# Altium schematic sheet DISPLAY-RELE.SchDoc(DISPLAY-RELE)
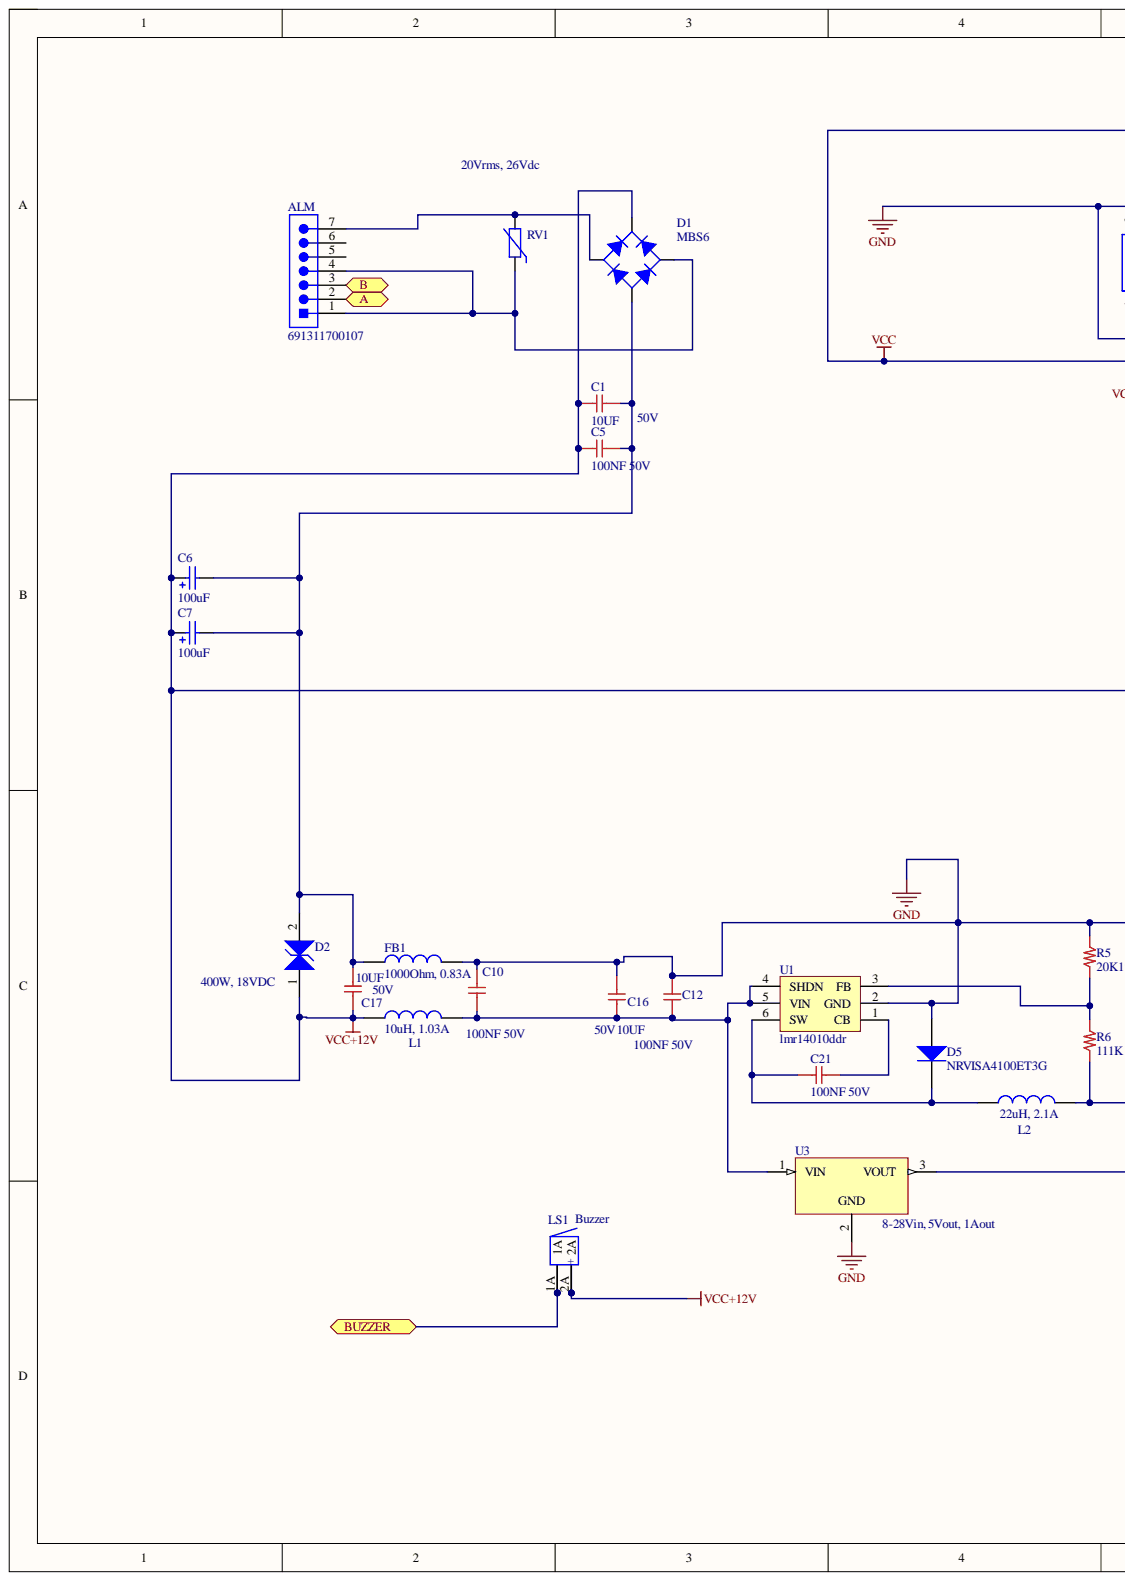
[diagram p0: DISPLAY-RELE.SchDoc, left]
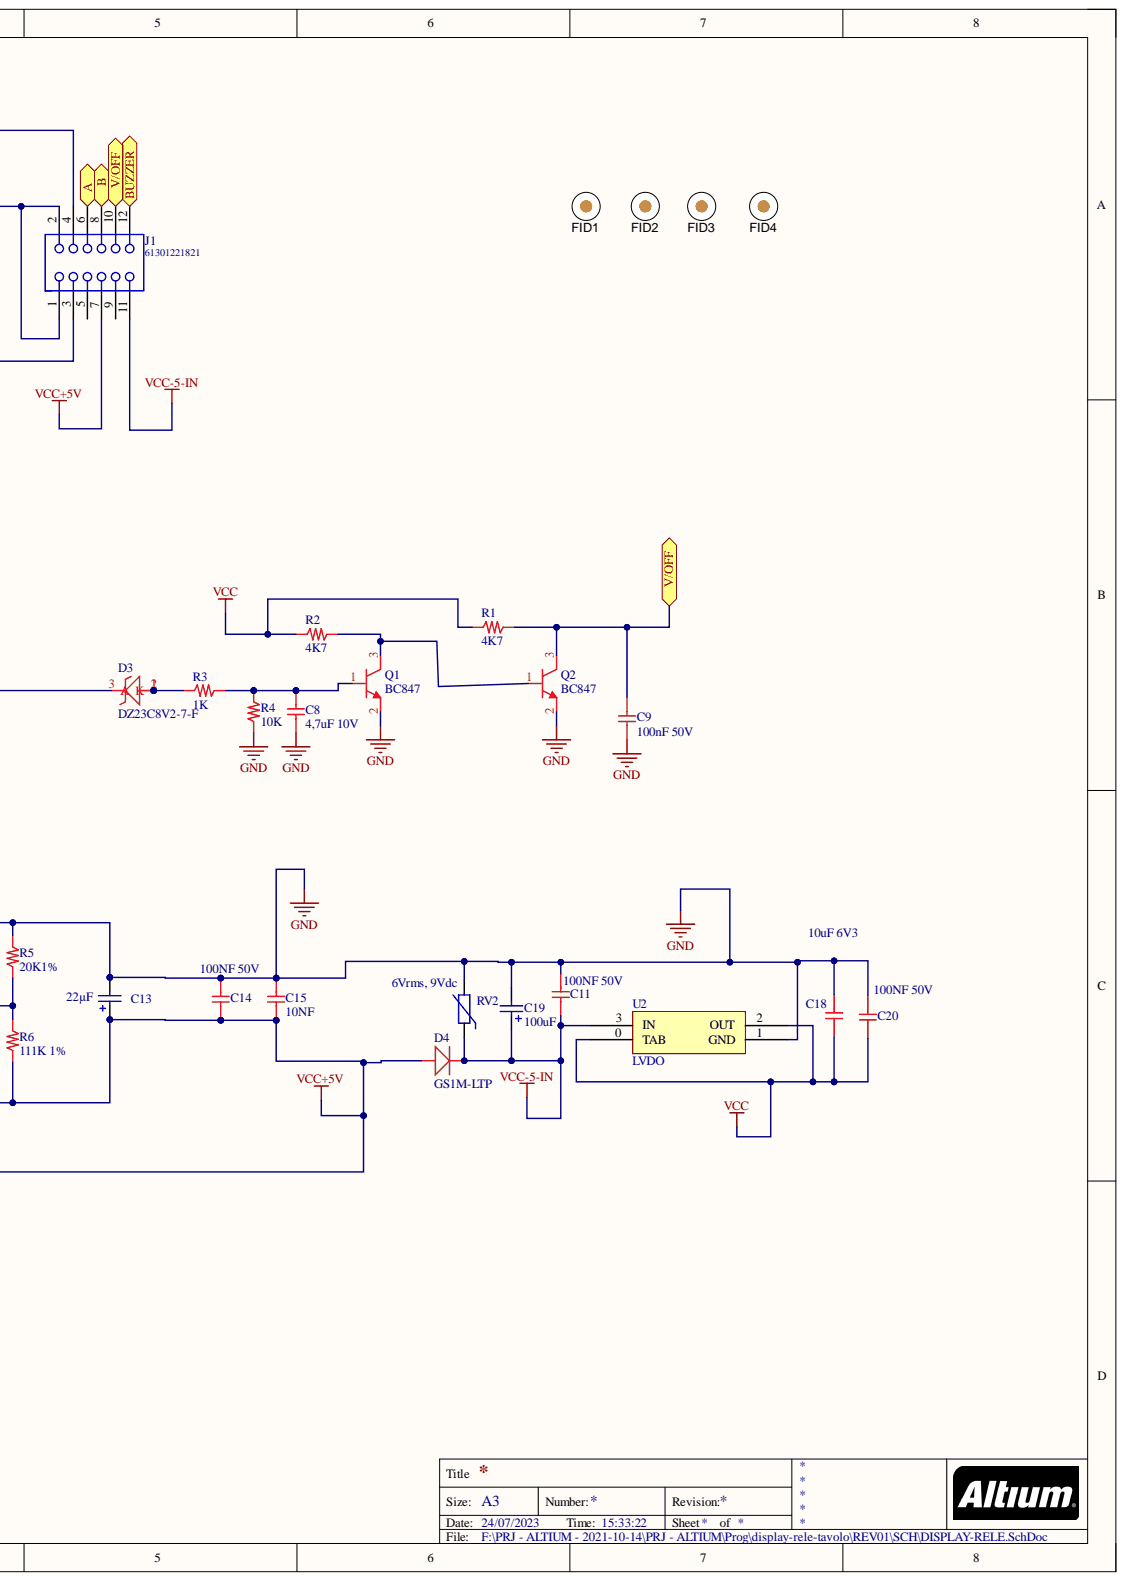
[diagram p1: DISPLAY-RELE.SchDoc, right]

## Parts
ALM  691311700107  [691311700107]
C1  10UF  Tensione: 50V  [1206_C]
C5  100NF 50V  [0603_c]
C6  value 100uF  [WCAP-ASLL_6.3x7.7]  (hidden comment: 865060445005)
C7  value 100uF  [WCAP-ASLL_6.3x7.7]  (hidden comment: 865060445005)
C8  4,7uF 10V  [0603_c]
C9  100nF 50V  [0603_c]
C10  100NF 50V  [0603_c]
C11  100NF 50V  [0603_c]
C12  100NF 50V  [0603_c]
C13  22µF  [WCAP-PSLP_D4H5.5]
C14  100NF 50V  [0603_c]
C15  10NF  [0603_c]
C16  10UF  Tensione: 50V  [1206_C]
C17  10UF  Tensione: 50V  [1206_C]
C18  10uF 6V3  [0603_c]
C19  value 100uF  [WCAP-ASLL_6.3x7.7]  (hidden comment: 865060445005)
C20  100NF 50V  [0603_c]
C21  100NF 50V  [0603_c]
D1  MBS6  [HD01-T SOP4]
D2  400W, 18VDC  [WE-TVSP_DO-214AC_Bidirectional]
D3  DZ23C8V2-7-F  [SOT23]
D4  GS1M-LTP  [SMA]
D5  NRVISA4100ET3G  [DO-214AC -B]
FB1  1000Ohm, 0.83A  Rated Current: 0.83A  [WE-CBF_0603_Wide Band]
FID1  Fiducial  [Fiducial10-20]  (value not drawn)
FID2  Fiducial  [Fiducial10-20]  (value not drawn)
FID3  Fiducial  [Fiducial10-20]  (value not drawn)
FID4  Fiducial  [Fiducial10-20]  (value not drawn)
J1  61301221821  [61301221821]
L1  10uH, 1.03A  Rated Current: 1.03A  [WE-LQS_3012]
L2  22uH, 2.1A  Rated Current: 2.1A  [WE-LQS_6045]
LS1  Buzzer  [BUZZER-14mm]
Q1  BC847  [SOT23]
Q2  BC847  [SOT23]
R1  4K7  [0603_R]
R2  4K7  [0603_R]
R3  1K  [0603_R]
R4  10K  [0603_R]
R5  20K1%  [0603_R]
R6  111K 1%  [0603_R]
RV1  20Vrms, 26Vdc  [WE-VD_5mm_820452001]
RV2  6Vrms, 9Vdc  DC Operating Voltage: 9Vdc  [WE-VS_0805]
U1  lmr14010ddr  [SOT23-6_N]
U2  LVDO  [SOT-223]
U3  8-28Vin, 5Vout, 1Aout  [MagI3C-FDSM_SIP-3_L11.6W8.5H10.5]

## Ports
A, B, BUZZER, V/OFF

## Nets
GND: C8.2 C9.2 C10.2 C11.2 C12.2 C13.2 C14.2 C15.2 C16.1 C18.1 C19.2 C20.2 D5.2 FB1.2 J1.1 J1.2 Q1.2 Q2.2 R4.2 R5.1 RV2.2 U1.2(GND) U2.1(GND) U3.2
VCC: C18.2 C20.1 J1.3 J1.4 R1.2 R2.2 U2.0(TAB) U2.2(OUT)
VCC+5V: C13.1 C14.1 C15.1 D4.1 J1.7 L2.2 R6.2 U3.3
VCC+12V: C1.2 C5.2 C6.1 C7.1 C17.2 D1.1 D2.1 D3.3 L1.1 LS1.2 LS1.2A
VCC-5-IN: C11.1 C19.1 D4.2 J1.11 RV2.1 U2.3(IN)
A: ALM.2 J1.6 ; port A
B: ALM.3 J1.8 ; port B
BUZZER: J1.12 LS1.1(1A) LS1.1A ; port BUZZER
V/OFF: C9.1 J1.10 Q2.3 R1.1 ; port V/OFF
NetALM_1: ALM.1 ALM.4 D1.3 RV1.1
NetALM_7: ALM.7 D1.4 RV1.2
NetC1_1: C1.1 C5.1 C6.2 C7.2 C17.1 D1.2 D2.2 FB1.1
NetC8_1: C8.1 Q1.1 R3.1 R4.1
NetC10_1: C10.1 C12.1 C16.2 L1.2 U1.4(SHDN) U1.5(VIN) U3.1
NetC21_1: C21.1 D5.1 L2.1 U1.6(SW)
NetC21_2: C21.2 U1.1(CB)
NetD3_1: D3.1 D3.2 R3.2
NetQ1_3: Q1.3 Q2.1 R2.1
NetR5_2: R5.2 R6.1 U1.3(FB)

Not connected: ALM 5 6; J1 5 9

## Library data of the parts
ALM = 691311700107: WR-TBL Serie 3117 Close Vertical PCB Header, Pluggable, THT, pitch 5mm, 7p; Manufacturer: Wurth Elektronik; Modularity: No; Pin Number: 7; Rated Current [UL]: 20A; Working Voltage [UL]: 300VAC; Application: Pluggable; Component Kind: Standard; ComponentLink1Description: Manufacturer; ComponentLink1URL: http://www.we-online.de; ComponentLink2Description: Datasheet; ComponentLink2URL: https://katalog.we-online.de/em/datasheet/691311700107.pdf; Library Name: WR-TBL_Pluggable PCB Header.SchLib; Manufacturer Part Number: 691311700107; Mounting Technology: THT; Operating Temperature: -40 to +105degC; Packaging: Box; PCB/Cable/Panel: PCB; Pitch: 5mm; Published Date: Feburary 2021; Type: Vertical
C1 C16 C17 = 10UF 50V: Cap X5R 10UF 50V; Cod. Produttore: GRM31CR61H106KA12L; Produttore: MURATA; Cod. Farnell: 2666583; Component Kind: Standard; Library Name: CapSMD1206.SchLib; Temperature Range: Da -55°C a +125°C
C5 C8-C12 C14 C20 C21 = 100NF 50V: Cap X7R 100nF 50V Flex Terminal; Cod. Interno: COCS50665050104; Cod. Produttore: CL10B104KB8SFNC; Produttore: Samsung; Cod. DigiKey: 1276-1936-2-ND; Component Kind: Standard; Library Name: CapSMD0603.SchLib
C6 C7 C19 = 865060445005: WCAP-ASLL Aluminum Electrolytic Capacitors, size Ф6.3x7.7mm, 25V DC, 100uF; Rated Voltage: 25V DC; Component Kind: Standard; ComponentLink1Description: Manufacturer; ComponentLink1URL: http://www.we-online.de; ComponentLink2Description: Datasheet; ComponentLink2URL: http://katalog.we-online.de/pbs/datasheet/865060445005.pdf; Library Name: WCAP-ASLL.SchLib; Life Cycle: 2000h; Operating Temperature: -55 °C up to +105 °C; Part Number: 865060445005; Published Date: June 2017; Size: Ф6.3x7.7mm; Publisher: Wurth Elektronik
C13 = 875105240003: WCAP-PSLP Aluminum Polymer Capacitor, V-Chip SMT, D4 x H5.5mm, 22µF, 10V; Manufacturer: Wurth Elektronik; Capacitance Tolerance: +/-20%; Dissipation Factor: 8%; Endurance: 2000h; ESR: 45mΩ; Leakage Current: 300µA; Ripple Current: 1200mA; Technical Reference: PSEB055220M010DVCTAB000; Capacitance: 22µF; Category: Aluminum Polymer Capacitors; Component Kind: Standard; ComponentLink1Description: Website Link; ComponentLink1URL: https://www.we-online.com/redexpert/article/875105240003?ad; ComponentLink2Description: Datasheet Link; ComponentLink2URL: https://www.we-online.com/redexpert/spec/875105240003?ad; Diameter: 4mm; Height: 5.5mm; Library Name: WCAP-PSLP.SchLib; Manufacturer Part Number: 875105240003; Match Code: WCAP-PSLP; Mount: Surface Mount; Operating Temperature Max: 105°C; Operating Temperature Min: -55°C; Packaging: Tape and Reel; Rated Voltage (DC): 10V
C15 = 10NF 50V: Cap X7R 10nF 50V; Cod. Interno: COCS 556655000103; Cod. Produttore: CL05B103KB5NNNC; Produttore: Samsung; Cod. DigiKey: 1276-1028-2-ND; Component Kind: Standard; Library Name: CapSMD0603.SchLib
C18 = 10uF 6V3: 10uF 6V3, ±10%, X5R 0603; Cod. Interno: COCS??; Cod. Produttore: C1608X5R1C335K080AC; Produttore: TDK; Component Kind: Standard; Library Name: CapSMD0603.SchLib
D1 = HD01-T: Full Wave Diode Bridge; Component Kind: Standard; Library Name: HSW.SchLib; Publisher: Altium Limited
D2 = 824501181: WE-TVSP Power TVS Diode, DO-214AC, 400W, 18VDC, Bidirectional; (Forward) Peak Pulse Current: 13.7A; (Reverse) Breakdown Voltage: 21.05V; Clamping Voltage: 29.2V; DC Operating Voltage: 18V; Component Kind: Standard; ComponentLink1Description: Manufacturer; ComponentLink1URL: http://www.we-online.de; ComponentLink2Description: Datasheet; ComponentLink2URL: https://katalog.we-online.com/pbs/datasheet/824501181.pdf; Library Name: WE-TVSP_DO-214AC_Bidirectional.SchLib; Mounting Technology: SMT; Operating Temperature: -65 to +150degC; Packaging: Tape and Reel; Part Number: 824501181; Polarity: Bidirectional; Published Date: March 2019; Size: DO-214AC; Steady State Power Dissipation: 400W; Publisher: Wurth Elektronik
D3 = ZENER 8V2 500mW: DIODE ZENER 8V2 500MW SOT23; Cod. Interno: ZENS5235PLVA650A; Cod. Produttore: PLVA650A; Produttore: NXP; Cod. DigiKey: 568-6471-2-ND; Component Kind: Standard; Library Name: DiodiZENER.SchLib
D4 = GS1M-LTP: 1 Amp Glass  Passivated Rectifier  50 - 1000 Volts; Cod. Interno: DIO 341004148; Cod. Produttore: 1N4148; If: 200mA; Ifrp: 450mA; Produttore: NXP; Vr: 100V; Component Kind: Standard; Library Name: Diodi.SchLib
D5 = BYS-10-45: High Conductance Fast Diode; Code_JEDEC: DO-204-AH; Component Kind: Standard; ComponentLink1Description: Manufacturer Link; ComponentLink1URL: http://www.fairchildsemi.com/; ComponentLink2Description: Datasheet; ComponentLink2URL: http://www.fairchildsemi.com/ds/1N%2F1N4148.pdf; DatasheetVersion: 1997; LatestRevisionDate: 17-Jul-2002; LatestRevisionNote: Re-released for DXP Platform.; PackageDescription: Diode, Axial; Body 3.8 x 1.9 mm (LxDia. max), Lead Dia. 0.53 mm (max); PackageReference: DO-35; Published: 8-Jun-2000; Library Name: HSW.SchLib; Publisher: Altium Limited
FB1 = 742792662: WE-CBF SMT EMI Suppression Ferrite Bead, size 0603, 1000Ohm, 0.83A; DC Resistance max.: 0.3Ohm; Impedance max.: 1050Ohm; Type: Wide Band; Component Kind: Standard; ComponentLink1Description: Manufacturer; ComponentLink1URL: http://www.we-online.de; ComponentLink2Description: Datasheet; ComponentLink2URL: https://katalog.we-online.com/pbs/datasheet/742792662.pdf; Impedance@100 MHz: 1000Ohm; Library Name: WE-CBF_0603.SchLib; Mounting Technology: SMT; Operating Temperature: -55 to +125degC; Packaging: Tape and Reel; Part Number: 742792662; Published Date: March 2019; Size: 0603; Publisher: Wurth Elektronik
FID1-FID4 = Fiducial: Fiducial mark.  There is nothing to buy or mount.; SymbolCreator: codlsc; ClassName: FiducialMark; Component Kind: Standard; CompType: Fiducial; LatestRevisionDate: 2015-10-18; LatestRevisionNote: Added Alternate PartNumber and Alternate Manufacturer parameters to appropriate components.; Manufacturer: N/A; MountType: N/A; PackageReference: N/A; PartNumber: N/A; SymbolVersion: 2009-11-4; Library Name: HSW.SchLib; Publisher: Texas Instruments
J1 = 61301221821: WR-PHD Socket Header, THT, pitch 2.54mm, Dual Row, Vertical, 12p; Manufacturer: Wurth Elektronik; Contact Resistance: 20mOhm; Pin Number: 12; Rated Current: 3A; Withstanding Voltage: 500VAC; Working Voltage: 250VAC; Component Kind: Standard; ComponentLink1Description: Manufacturer; ComponentLink1URL: http://www.we-online.de; ComponentLink2Description: Datasheet; ComponentLink2URL: https://www.we-online.com/catalog/datasheet/61301221821.pdf; Gender: Socket Header; Library Name: WR-PHD_Socket Header_2.54mm.SchLib; Manufacturer Part Number: 61301221821; Mounting Technology: THT; Operating Temperature: -40 to +125degC; Orientation: Vertical; Packaging: Tray; Pitch: 2.54mm; Published Date: May 2020; Row Number: Dual
L1 = 74404031100A: WE-LQS SMT Power Inductor, size 3012, 10uH, 1.03A; Manufacturer: Wurth Elektronik; DC Resistance: 254mOhm; Saturation Current: 0.58A; Self Resonant Frequency: 58MHz; Component Kind: Standard; ComponentLink1Description: Manufacturer; ComponentLink1URL: http://www.we-online.de; ComponentLink2Description: Datasheet; ComponentLink2URL: https://www.we-online.com/catalog/datasheet/74404031100A.pdf; Inductance: 10uH; Library Name: WE-LQS_3012.SchLib; Manufacturer Part Number: 74404031100A; Mounting Technology: SMT; Operating Temperature: -40 to +125degC; Packaging: Tape and Reel; Published Date: May 2020; Size: 3012
L2 = 74404064220: WE-LQS SMT Power Inductor, size 6045, 22uH, 2.1A; Manufacturer: Wurth Elektronik; DC Resistance: 89mOhm; Saturation Current: 2.5A; Self Resonant Frequency: 15MHz; Component Kind: Standard; ComponentLink1Description: Manufacturer; ComponentLink1URL: http://www.we-online.de; ComponentLink2Description: Datasheet; ComponentLink2URL: https://www.we-online.com/catalog/datasheet/74404064220.pdf; Inductance: 22uH; Library Name: WE-LQS_6045.SchLib; Manufacturer Part Number: 74404064220; Mounting Technology: SMT; Operating Temperature: -40 to +125degC; Packaging: Tape and Reel; Published Date: March 2020; Size: 6045
LS1 = Buzzer: Magnetic Transducer Buzzer; Component Kind: Standard; ComponentLink1Description: Manufacturer Link; ComponentLink1URL: http://www.megastar.com/linecard/buzzmode/index.php; DatasheetVersion: 17-Dec-2003; LatestRevisionDate: 17-Dec-2003; LatestRevisionNote: Schematic revised, PCB Footprint replaced.; PackageDescription: Magnetic Transducer Buzzer; PackageReference: ABSM-1574; Published: 24-Mar-1999; Library Name: HSW.SchLib; Publisher: Altium Limited
Q1 Q2 = MMBT3904LT1G: NPN Si Digital Transistor; Cod. Interno: TRXS 110MMBT3904LT1G; Ft: 300MHz; Ic: 200mA; Produttore: ON Semiconductor; Ptot: 300mW; Vcb: 60V; Vce: 40V; Cod. Produttore: MMBT3904LT1G; Component Kind: Standard; Library Name: TransitorSMD.SCHLIB
R1-R4 = 2K7: Res Film Spesso 1/16W 2K7 5%; Cod. Interno: RESS4166556B0272; Cod. Produttore: CRCW06032K70JNEA; Produttore: VISHAY; Component Kind: Standard; Library Name: ResSMD0603.SchLib
R5 R6 = 110K 1%: Res Film Spesso 1/16W 110K 1%; Component Kind: Standard; Library Name: ResSMD0603.SchLib
RV1 = 820452001: WE-VD Disk Varistor, size 5mm, 20Vrms, 26Vdc; (Channel) Input Capacitance: 880pF; (Reverse) Breakdown Voltage: 33V; (Reverse) Peak Pulse Current: 250A; Clamping Voltage: 73V; Energy Absorbtion: 1.3J; Power Dissipation: 0.01W; AC Operating Voltage: 20Vrms; Component Kind: Standard; ComponentLink1Description: Manufacturer; ComponentLink1URL: http://www.we-online.de; ComponentLink2Description: Datasheet; ComponentLink2URL: https://katalog.we-online.com/pbs/datasheet/820452001.pdf; DC Operating Voltage: 26Vdc; Mounting Technology: THT; Operating Temperature: -40 to +85degC; Packaging: Tape and Reel; Part Number: 820452001; Published Date: March 2019; Size: 5mm; Library Name: MOV_5mm.SchLib; Publisher: Wurth Elektronik
RV2 = 82550060: WE-VS SMD Varistor, size 0805, 6Vrms, 9Vdc; Clamping Voltage: 20V; Energy Dissipation: 0.1J; Varistor Voltage: 12V; Withstanding Surge Current: 80A; AC Operating Voltage: 6Vrms; Component Kind: Standard; ComponentLink1Description: Manufacturer; ComponentLink1URL: http://www.we-online.de; ComponentLink2Description: Datasheet; ComponentLink2URL: https://katalog.we-online.com/pbs/datasheet/82550060.pdf; Library Name: WE-VS_0805.SchLib; Mounting Technology: SMT; Operating Temperature: -40 to +85degC; Packaging: Tape and Reel; Part Number: 82550060; Published Date: March 2019; Size: 0805; Publisher: Wurth Elektronik
U2 = LD1117S33TR: REGOLATORE IN; Component Kind: Standard; Library Name: HSW.SchLib
U3 = 173010578: MagI3C-FDSM Fixed Step Down Regulator Module, SIP-3, 8-28Vin, 5Vout, 1Aout; Manufacturer: Wurth Elektronik; Input Voltage max.: 28V; Input Voltage min.: 8V; Output Current: 1A; Output Voltage: 5V; Component Kind: Standard; ComponentLink1Description: Manufacturer Link; ComponentLink1URL: http://www.we-online.de; ComponentLink2Description: Datasheet; ComponentLink2URL: https://www.we-online.com/catalog/datasheet/173010578.pdf; Height: 10.5mm; Length: 11.6mm; Library Name: MagI3C-FDSM.SchLib; Manufacturer Part Number: 173010578; Mounting Technology: THT; Operating Temperature max.: 85C; Operating Temperature min.: -40C; Packaging: Tube; Size: SIP-3; Width: 8.5mm
U1 = lmr14010ddr: Component Kind: Standard; Library Name: HSW.SchLib
